# Altium schematic sheet STM32-ROMI_Rev_A_1_STM32.SchDoc(STM32-ROMI_Rev_A_1_STM32)
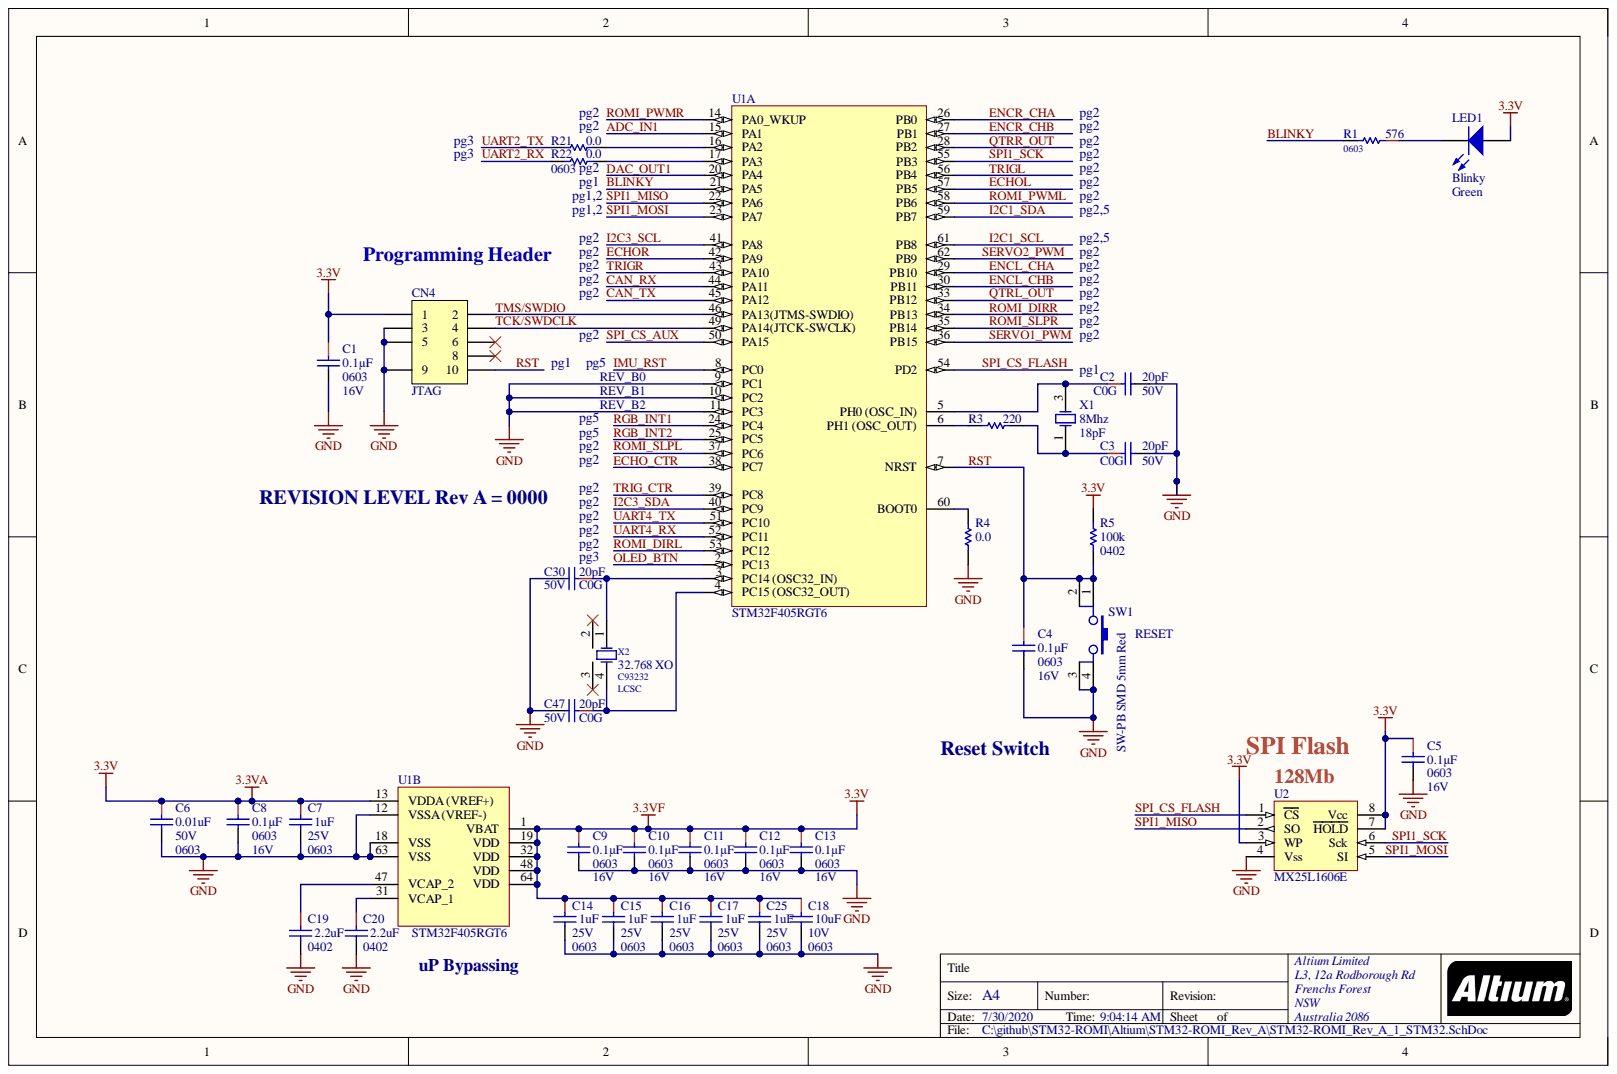
[diagram p0: STM32-ROMI_Rev_A_1_STM32.SchDoc]

## Parts
C1  Capacitance: 0.1µF  Package / Case: 0603  Voltage - Rated: 16V  [CAPC0603(1608)_L]  (hidden comment: 0.1uF)
C2  Capacitance: 20pF  Temperature Coefficient: C0G  Voltage - Rated: 50V  [CAPC0402(1005)_L]  (hidden comment: 20pF 0402)
C3  Capacitance: 20pF  Temperature Coefficient: C0G  Voltage - Rated: 50V  [CAPC0402(1005)_L]  (hidden comment: 20pF 0402)
C4  Capacitance: 0.1µF  Package / Case: 0603  Voltage - Rated: 16V  [CAPC0603(1608)_L]  (hidden comment: 0.1uF)
C5  Capacitance: 0.1µF  Package / Case: 0603  Voltage - Rated: 16V  [CAPC0603(1608)_L]  (hidden comment: 0.1uF)
C6  0.01uF  Package / Case: 0603  Voltage - Rated: 50V  [CAPC0603(1608)_L]
C7  1uF  Package / Case: 0603  Voltage - Rated: 25V  [CAPC0603(1608)_N]
C8  0.1uF  Capacitance: 0.1µF  Package / Case: 0603  Voltage - Rated: 16V  [CAPC0603(1608)_L]
C9  Capacitance: 0.1µF  Package / Case: 0603  Voltage - Rated: 16V  [CAPC0603(1608)_L]  (hidden comment: 0.1uF)
C10  Capacitance: 0.1µF  Package / Case: 0603  Voltage - Rated: 16V  [CAPC0603(1608)_L]  (hidden comment: 0.1uF)
C11  Capacitance: 0.1µF  Package / Case: 0603  Voltage - Rated: 16V  [CAPC0603(1608)_L]  (hidden comment: 0.1uF)
C12  Capacitance: 0.1µF  Package / Case: 0603  Voltage - Rated: 16V  [CAPC0603(1608)_L]  (hidden comment: 0.1uF)
C13  Capacitance: 0.1µF  Package / Case: 0603  Voltage - Rated: 16V  [CAPC0603(1608)_L]  (hidden comment: 0.1uF)
C14  1uF  Package / Case: 0603  Voltage - Rated: 25V  [CAPC0603(1608)_N]
C15  1uF  Package / Case: 0603  Voltage - Rated: 25V  [CAPC0603(1608)_N]
C16  1uF  Package / Case: 0603  Voltage - Rated: 25V  [CAPC0603(1608)_N]
C17  1uF  Package / Case: 0603  Voltage - Rated: 25V  [CAPC0603(1608)_N]
C18  10uF  Package / Case: 0603  Voltage - Rated: 10V  [CAPC0603(1608)_L]
C19  2.2uF  Package / Case: 0402  [CAPC0402(1005)_L]
C20  2.2uF  Package / Case: 0402  [CAPC0402(1005)_L]
C25  1uF  Package / Case: 0603  Voltage - Rated: 25V  [CAPC0603(1608)_N]
C30  Capacitance: 20pF  Temperature Coefficient: C0G  Voltage - Rated: 50V  [CAPC0402(1005)_L]  (hidden comment: 20pF 0402)
C47  Capacitance: 20pF  Temperature Coefficient: C0G  Voltage - Rated: 50V  [CAPC0402(1005)_L]  (hidden comment: 20pF 0402)
CN4  JTAG  [BOBS FAVORITE DEBUG HDR]
LED1  Blinky  Color: Green  [SM-LED-0603]
R1  576  Part Size: 0603  [RESC0603(1608)_N]
R3  220  [RESC0402(1005)_L]
R4  0  Resistance (Ohms): 0.0  [RESC0402(1005)_L]
R5  Resistance (Ohms): 100k  Supplier Device Package: 0402  [RESC0402(1005)_L]  (hidden comment: 100K 0402)
R21  Resistance (Ohms): 0.0  [RESC0603(1608)_N]  (hidden comment: 0 0603)
R22  Package / Case: 0603  Resistance (Ohms): 0.0  [RESC0603(1608)_N]  (hidden comment: 0 0603)
SW1  RESET  [SW TACT Red 6mm x 6mm]
U1  STM32F405RGT6  [STM-LQFP64_N]  (parts A,B)
U2  MX25L1606E  [SOG.050/8D]
X1  8Mhz  Load Capacitance: 18pF  [SMXOSC/5x3.2]
X2  32.768 XO  Supplier 2: LCSC  Supplier Part Number 2: C93232  [OSCABS]

## Nets
3.3V: C1.1 C5.1 C6.1 C7.1 C8.1 C9.1 C10.1 C11.1 C12.1 C13.1 C14.1 C15.1 C16.1 C17.1 C18.1 C25.1 CN4.1 LED1.1 R5.2 U1.1(VBAT) U1.13(VDDA_(VREF+)) U1.19(VDD) U1.32(VDD) U1.48(VDD) U1.64(VDD) U2.3(WP) U2.7(HOLD) U2.8(Vcc)
GND: C1.2 C2.2 C3.2 C4.2 C5.2 C6.2 C7.2 C8.2 C9.2 C10.2 C11.2 C12.2 C13.2 C14.2 C15.2 C16.2 C17.2 C18.2 C19.2 C20.2 C25.2 C30.2 C47.2 CN4.3 CN4.5 CN4.9 R4.1 SW1.3 SW1.4 U1.9(PC1) U1.10(PC2) U1.11(PC3) U1.12(VSSA_(VREF-)) U1.18(VSS) U1.63(VSS) U2.4(Vss)
ADC_IN1: U1.15(PA1)
BLINKY: R1.2 U1.21(PA5)
CAN_RX: U1.44(PA11)
CAN_TX: U1.45(PA12)
DAC_OUT1: U1.20(PA4)
ECHO_CTR: U1.38(PC7)
ECHOL: U1.57(PB5)
ECHOR: U1.42(PA9)
ENCL_CHA: U1.29(PB10)
ENCL_CHB: U1.30(PB11)
ENCR_CHA: U1.26(PB0)
ENCR_CHB: U1.27(PB1)
I2C1_SCL: U1.61(PB8)
I2C1_SDA: U1.59(PB7)
I2C3_SCL: U1.41(PA8)
I2C3_SDA: U1.40(PC9)
IMU_RST: U1.8(PC0)
OLED_BTN: U1.2(PC13)
QTRL_OUT: U1.33(PB12)
QTRR_OUT: U1.28(PB2)
RGB_INT1: U1.24(PC4)
RGB_INT2: U1.25(PC5)
ROMI_DIRL: U1.53(PC12)
ROMI_DIRR: U1.34(PB13)
ROMI_PWML: U1.58(PB6)
ROMI_PWMR: U1.14(PA0_WKUP)
ROMI_~{SLPL}: U1.37(PC6)
ROMI_~{SLPR}: U1.35(PB14)
RST: C4.1 CN4.10 R5.1 SW1.1 SW1.2 U1.7(NRST)
SERVO1_PWM: U1.36(PB15)
SERVO2_PWM: U1.62(PB9)
SPI1_MISO: U1.22(PA6) U2.2(SO)
SPI1_MOSI: U1.23(PA7) U2.5(SI)
SPI1_SCK: U1.55(PB3) U2.6(Sck)
SPI_CS_AUX: U1.50(PA15)
SPI_CS_FLASH: U1.54(PD2) U2.1(CS)
TCK/SWDCLK: CN4.4 U1.49(PA14(JTCK-SWCLK))
TMS/SWDIO: CN4.2 U1.46(PA13(JTMS-SWDIO))
TRIG_CTR: U1.39(PC8)
TRIGL: U1.56(PB4)
TRIGR: U1.43(PA10)
UART2_RX: R22.2
UART2_TX: R21.2
UART4_RX: U1.52(PC11)
UART4_TX: U1.51(PC10)
NetC2_1: C2.1 U1.5(PH0_(OSC_IN)) X1.3
NetC3_1: C3.1 R3.1 X1.1
NetC19_1: C19.1 U1.47(VCAP_2)
NetC20_1: C20.1 U1.31(VCAP_1)
NetC30_1: C30.1 U1.3(PC14_(OSC32_IN)) X2.1
NetC47_1: C47.1 U1.4(PC15_(OSC32_OUT)) X2.4
NetLED1_2: LED1.2 R1.1
NetR3_2: R3.2 U1.6(PH1_(OSC_OUT))
NetR4_2: R4.2 U1.60(BOOT0)
NetR21_1: R21.1 U1.16(PA2)
NetR22_1: R22.1 U1.17(PA3)

Not connected: CN4 6 8; X2 2 3

## Library data of the parts
C1 C4 C5 C8-C13 = CAP CER 0.1UF 16V 10% X7R 0603: 0.1uF 0603 16V; Manufacturer: Kemet; Manufacturer Part Number: C0603C104K4RACTU; Temperature Coefficient: X7R; Tolerance: ±10%; Applications: General Purpose; Category: Capacitors; Component Kind: Standard; ComponentLink1Description: http://www.kemet.com/datasheets&C0603C104K4RACTU; ComponentLink1URL: http://www.kemet.com/datasheets&C0603C104K4RACTU; Features: -; Height - Seated (Max): -; Lead Spacing: -; Lead Style: -; Library Name: JLCPCB_Passives.IntLib; Mounting Type: Surface Mount, MLCC; Operating Temperature: -55°C ~ 125°C; Packaging: Tape & Reel (TR); Pricing 1: 4000=0.00414, 8000=0.00378, 12000=0.0036, 28000=0.00342, 100000=0.00248 (USD); Ratings: -; RoHS: RoHS Compliant; Size / Dimension: 0.063" L x 0.031" W (1.60mm x 0.80mm); Stock 1: 2360000; Supplier 1: Digi-Key; Supplier Part Number 1: 399-1096-2-ND; Thickness (Max): 0.034" (0.87mm)
C2 C3 C30 C47 = CAP CER 20PF 50V 2% NP0 0402: Manufacturer: Murata Electronics North America; Manufacturer Part Number: GRM1555C1H200GA01D; Tolerance: ±2%; Applications: General Purpose; Category: Capacitors; Component Kind: Standard; ComponentLink1Description: http://www.murata.com/~/media/webrenewal/support/library/catalog/products/capacitor/mlcc/c02e.ashx; ComponentLink1URL: http://www.murata.com/~/media/webrenewal/support/library/catalog/products/capacitor/mlcc/c02e.ashx; Description: 20pF 0402; Features: -; Height - Seated (Max): -; Lead Spacing: -; Lead Style: -; Library Name: OLogic-Passive.IntLib; Mounting Type: Surface Mount, MLCC; Operating Temperature: -55°C ~ 125°C; Packaging: Cut Tape (CT); Pricing 1: 1=0.11, 10=0.082, 50=0.0444, 100=0.0376, 250=0.03076, 500=0.02632, 1000=0.0201, 5000=0.01675 (USD); Ratings: -; RoHS: RoHS Compliant; Size / Dimension: 0.039" L x 0.020" W (1.00mm x 0.50mm); Stock 1: 80973; Supplier 1: Digi-Key; Supplier Part Number 1: 490-6217-1-ND; Thickness (Max): 0.022" (0.55mm); Creator: osman
C6 = CAP CER 0.01uF 50V 10% X7R 0603: 0.01uF 50V 0603; Manufacturer: Kemet; Manufacturer Part Number: C0603C103K5RACTU; Capacitance: 10000pF; Temperature Coefficient: X7R; Tolerance: ±10%; Applications: General Purpose; Category: Capacitors; ClassName: MCU; Component Kind: Standard; ComponentLink1Description: http://www.kemet.com/docfinder?Partnumber=C0603C103K5RACTU; ComponentLink1URL: http://www.kemet.com/docfinder?Partnumber=C0603C103K5RACTU; Features: -; Height - Seated (Max): -; Lead Spacing: -; Lead Style: -; Library Name: OLogic-Passive.IntLib; Mounting Type: Surface Mount, MLCC; Operating Temperature: -55°C ~ 125°C; Packaging: Cut Tape (CT); Pricing 1: 1=0.1, 10=0.02, 25=0.014, 50=0.0106, 100=0.009, 250=0.00736, 1000=0.0048 (USD); Ratings: -; RoHS: RoHS Compliant; Size / Dimension: 0.063" L x 0.031" W (1.60mm x 0.80mm); Stock 1: 1304139; Supplier 1: Digi-Key; Supplier Part Number 1: 399-1091-1-ND; Thickness (Max): 0.034" (0.87mm)
C7 C14-C17 C25 = CAP CER 1UF 25V 10% X7R 0603: 1uF 0603 25V; Manufacturer: TDK Corporation; Manufacturer Part Number: CGA3E1X7R1E105K080AC; Temperature Coefficient: X7R; Tolerance: ±10%; Applications: Automotive; Category: Capacitors; Component Kind: Standard; ComponentLink1Description: https://product.tdk.com/info/en/catalog/datasheets/mlcc_automotive_general_en.pdf; ComponentLink1URL: https://product.tdk.com/info/en/catalog/datasheets/mlcc_automotive_general_en.pdf; Failure Rate: -; Features: -; Height - Seated (Max): -; Lead Spacing: -; Lead Style: -; Library Name: OLogic-Passive.IntLib; Mounting Type: Surface Mount, MLCC; Operating Temperature: -55°C ~ 125°C; Packaging: Cut Tape (CT); Part Status: Active; Pricing 1: 1=0.2, 10=0.135, 100=0.0686, 500=0.04696, 1000=0.04154 (USD); Ratings: AEC-Q200; RoHS: RoHS Compliant; Size / Dimension: 0.063" L x 0.032" W (1.60mm x 0.80mm); Stock 1: 360610; Supplier 1: Digi-Key; Supplier Part Number 1: 445-6931-1-ND; Thickness (Max): 0.035" (0.90mm)
C18 = CAP CER 10UF 10V 20% X5R 0603: 10uF 0603 10V; Manufacturer: Taiyo Yuden; Manufacturer Part Number: LMK107BBJ106MAHT; Capacitance: 10µF; Temperature Coefficient: X5R; Tolerance: ±20%; Applications: Automotive; Category: Capacitors; Component Kind: Standard; ComponentLink1Description: http://www.yuden.co.jp/productdata/catalog/en/mlcc_all_e.pdf; ComponentLink1URL: http://www.yuden.co.jp/productdata/catalog/en/mlcc_all_e.pdf; Features: -; Height - Seated (Max): -; Lead Spacing: -; Lead Style: -; Library Name: OLogic-Passive.IntLib; Mounting Type: Surface Mount, MLCC; Operating Temperature: -55°C ~ 85°C; Packaging: Cut Tape (CT); Pricing 1: 1=0.4, 10=0.348, 50=0.2116, 100=0.1742, 250=0.13936, 500=0.12692, 1000=0.11224 (USD); Ratings: AEC-Q200; RoHS: RoHS Compliant; Size / Dimension: 0.063" L x 0.031" W (1.60mm x 0.80mm); Stock 1: 20252; Supplier 1: Digi-Key; Supplier Part Number 1: 587-3412-1-ND; Thickness (Max): 0.035" (0.90mm)
C19 C20 = CAP CER 2.2UF 35V 10% X5R 0402: 2.2uF 0402; Manufacturer: TDK Corporation; Manufacturer Part Number: C1005X5R1V225K050BC; Capacitance: 2.2µF; Temperature Coefficient: X5R; Tolerance: ±10%; Voltage - Rated: 35V; Applications: General Purpose; Category: Capacitors; Component Kind: Standard; ComponentLink1Description: http://product.tdk.com/en/catalog/datasheets/mlcc_commercial_general_en.pdf; ComponentLink1URL: http://product.tdk.com/en/catalog/datasheets/mlcc_commercial_general_en.pdf; Features: -; Height - Seated (Max): -; Lead Spacing: -; Lead Style: -; Library Name: OLogic-Passive.IntLib; Mounting Type: Surface Mount, MLCC; Operating Temperature: -55°C ~ 85°C; Packaging: Cut Tape (CT); Pricing 1: 1=0.32, 10=0.28, 100=0.14, 250=0.112, 500=0.102, 1000=0.092, 5000=0.076 (USD); Ratings: -; RoHS: RoHS Compliant; Size / Dimension: 0.039" L x 0.020" W (1.00mm x 0.50mm); Stock 1: 43689; Supplier 1: Digi-Key; Supplier Part Number 1: 445-9028-1-ND; Thickness (Max): 0.022" (0.55mm); Creator: osman
CN4 = CONN-2x5-SWD: SAMTEC - FTSH-105-01-L-DV - HEADER, 1.27MM, SMD, 10WAY; Connector Type: Header, Unshrouded; Number of Positions: 10; Number of Positions Loaded: All; Pitch: 0.050" (1.27mm); PN: SAM1161-05-ND; Vendor: Digikey; Category: Connectors, Interconnects; ClassName: mcu; Color: Black; Component Kind: Standard; ComponentLink1Description: http://www.samtec.com/documents/webfiles/cpdf/FTSH-1XX-XX-XXX-DV-XXX-MKT.pdf; ComponentLink1URL: http://www.samtec.com/documents/webfiles/cpdf/FTSH-1XX-XX-XXX-DV-XXX-MKT.pdf; Contact Finish: Gold; Contact Finish Thickness: 10µin (0.25µm); Contact Mating Length: 0.120" (3.05mm); Contact Type: Male Pin; Fastening Type: -; Features: -; Library Name: OLogic-Connectors.IntLib; Manufacturer: Samtec; Manufacturer Part Number: FTSH-105-01-L-DV; Mounting Type: Surface Mount; MPN: FTSH-105-01-L-DV; Number of Rows: 2; Packaging: Bulk; Pricing 1: 1=3.42, 10=2.963, 100=2.5073, 500=1.99444, 1000=1.62404, 5000=1.48158, 10000=1.4246 (USD); RoHS: RoHS Compliant; Row Spacing: 0.050" (1.27mm); Stock 1: 0; Supplier 1: Digi-Key; Supplier Part Number 1: SAM1161-05-ND; Termination: Solder
LED1 = LTST-C191KGKT: LED GREEN CLEAR THIN 0603 SMD; Manufacturer: Lite-On Inc; Current - Test: 20mA; Lens Style/Size: Rectangle with Flat Top, 1.1mm x 0.8mm; Millicandela Rating: 35mcd; Viewing Angle: 130°; Voltage - Forward (Vf) (Typ): 2V; Wavelength - Dominant: 571nm; Wavelength - Peak: 574nm; Category: Optoelectronics; Component Kind: Standard; ComponentLink1Description: http://optoelectronics.liteon.com/upload/download/DS22-2000-228/S_110_LTST-C191KGKT.pdf; ComponentLink1URL: http://optoelectronics.liteon.com/upload/download/DS22-2000-228/S_110_LTST-C191KGKT.pdf; Height: 0.55mm; Lens Type: Clear; Luminous Flux @ Current - Test: -; Manufacturer Part Number: LTST-C191KGKT; Mounting Type: Surface Mount; Package / Case: 0603 (1608 Metric); Packaging: Cut Tape (CT); Pricing 1: 1=0.3, 10=0.238, 100=0.1296, 250=0.0864, 500=0.07344, 1000=0.05616, 2500=0.05076 (USD); RoHS: RoHS Compliant; Size / Dimension: 1.60mm L x 0.80mm W; Stock 1: 84829; Supplier 1: Digi-Key; Supplier Part Number 1: 160-1446-1-ND; Library Name: Kyle-Diode-Opto-LED.IntLib
R1 = 576: 576OHMS ±1% 1/10W 0603; Manufacturer Part Number: 0603WAF5760T5E; Component Kind: Standard; Library Name: JLCPCB_Passives.IntLib; Part Supplier 1: LCSC
R3 = RES 220 OHM 1% 1/10W 0402: 220 0402; Manufacturer: Panasonic Electronic Components; Manufacturer Part Number: ERJ-2RKF2200X; Power (Watts): 0.1W, 1/10W; Temperature Coefficient: ±100ppm/°C; Tolerance: ±1%; Category: Resistors; Component Kind: Standard; ComponentLink1Description: http://industrial.panasonic.com/www-cgi/jvcr13pz.cgi?E+PZ+3+AOA0002+ERJ2RKF2200X+7+WW; ComponentLink1URL: http://industrial.panasonic.com/www-cgi/jvcr13pz.cgi?E+PZ+3+AOA0002+ERJ2RKF2200X+7+WW; Composition: Thick Film; Features: Automotive AEC-Q200; Height: 0.016" (0.40mm); Library Name: OLogic-Passive.IntLib; Number of Terminations: 2; Operating Temperature: -55°C ~ 155°C; Package / Case: 0402 (1005 Metric); Packaging: Cut Tape (CT); Pricing 1: 1=0.1, 50=0.0196, 100=0.0149, 250=0.01104, 500=0.00816, 1000=0.00544, 5000=0.00383 (USD); Resistance (Ohms): 220; RoHS: RoHS Compliant; Size / Dimension: 0.039" L x 0.020" W (1.00mm x 0.50mm); Stock 1: 372365; Supplier 1: Digi-Key; Supplier Device Package: 0402; Supplier Part Number 1: P220LCT-ND; Creator: osman
R4 = RES 0.0 OHM JUMPER 1/10W 0402: 0.0 0402; Manufacturer: Panasonic Electronic Components; Manufacturer Part Number: ERJ-2GE0R00X; Power (Watts): 0.1W, 1/10W; Tolerance: Jumper; Category: Resistors; Component Kind: Standard; ComponentLink1Description: http://industrial.panasonic.com/www-cgi/jvcr13pz.cgi?E+PZ+3+AOA0001+ERJ2GE0R00X+7+WW; ComponentLink1URL: http://industrial.panasonic.com/www-cgi/jvcr13pz.cgi?E+PZ+3+AOA0001+ERJ2GE0R00X+7+WW; Composition: Thick Film; Features: Automotive AEC-Q200; Height: 0.016" (0.40mm); Library Name: OLogic-Passive.IntLib; Number of Terminations: 2; Operating Temperature: -55°C ~ 155°C; Package / Case: 0402 (1005 Metric); Packaging: Cut Tape (CT); Pricing 1: 1=0.1, 50=0.0138, 100=0.0105, 250=0.0078, 500=0.00576, 1000=0.00384, 5000=0.0027 (USD); RoHS: RoHS Compliant; Size / Dimension: 0.039" L x 0.020" W (1.00mm x 0.50mm); Stock 1: 8429476; Supplier 1: Digi-Key; Supplier Device Package: 0402; Supplier Part Number 1: P0.0JCT-ND; Temperature Coefficient: -; Creator: osman
R5 = RES 100K OHM 1% 1/16W 0402: Manufacturer: Yageo; Manufacturer Part Number: RC0402FR-07100KL; Power (Watts): 0.1W, 1/10W; Temperature Coefficient: ±100ppm/°C; Tolerance: ±1%; Category: Resistors; Component Kind: Standard; ComponentLink1Description: http://industrial.panasonic.com/www-cgi/jvcr13pz.cgi?E+PZ+3+AOA0002+ERJ2RKF1003X+7+WW; ComponentLink1URL: http://industrial.panasonic.com/www-cgi/jvcr13pz.cgi?E+PZ+3+AOA0002+ERJ2RKF1003X+7+WW; Composition: Thick Film; Description: 100K 0402; Features: Automotive AEC-Q200; Height: 0.016" (0.40mm); Library Name: OLogic-Passive.IntLib; Number of Terminations: 2; Operating Temperature: -55°C ~ 155°C; Package / Case: 0402 (1005 Metric); Packaging: Cut Tape (CT); Pricing 1: 1=0.1, 50=0.0196, 100=0.0149, 250=0.01104, 500=0.00816, 1000=0.00544, 5000=0.00383 (USD); RoHS: RoHS Compliant; Size / Dimension: 0.039" L x 0.020" W (1.00mm x 0.50mm); Stock 1: 3706939; Supplier 1: Digi-Key; Supplier 2: Digi-Key; Supplier Part Number 1: 311-100KLRCT-ND; Supplier Part Number 2: RMCF0402FT100KCT-ND
R21 R22 = RES 0.0 OHM 1/4W JUMP 0603 SMD: Manufacturer: Vishay Dale; Manufacturer Part Number: CRCW06030000Z0EAHP; Power (Watts): 1/4W; Tolerance: Jumper; Category: Resistors; Component Kind: Standard; ComponentLink1Description: http://www.vishay.com/docs/20043/crcwhpe3.pdf; ComponentLink1URL: http://www.vishay.com/docs/20043/crcwhpe3.pdf; Composition: Thick Film; Description: 0 0603; Features: Automotive AEC-Q200 Qualified, Pulse Withstanding; Height: 0.022" (0.55mm); Library Name: OLogic-Passive.IntLib; Number of Terminations: 2; Packaging: Cut Tape (CT); Pricing 1: 10=0.202, 50=0.1088, 200=0.0625, 1000=0.04263 (USD); RoHS: RoHS Compliant; Signal Integrity: Res; Simulation: RESISTOR; Size / Dimension: 0.063" L x 0.033" W (1.60mm x 0.85mm); Stock 1: 204741; Supplier 1: Digi-Key; Supplier Device Package: 0603 (1608 Metric); Supplier Part Number 1: 541-0.0SBCT-ND; Temperature Coefficient: -
  R21: Package / Case: 0603
SW1 = SW-PB SMD 5mm Red: SWITCH TACTILE SPST-NO 0.05A 12V; Manufacturer: C&K Components; Manufacturer Part Number: PTS645SK50SMTR92 LFS; Actuator Height off PCB, from Pins: 5.00mm; Actuator Material: Polyamide (PA66), Nylon 6/6; Actuator Orientation: Top Actuated; Actuator Type: Standard; Contact Rating @ Voltage: 0.05A @ 12VDC; Illumination: Non-Illuminated; Mechanical Life: 100,000 Cycles; Operating Force: 260gf; Outline: 6.00mm x 6.00mm; Switch Function: Off-Mom; Category: Switches; Circuit: SPST-NO; ClassName: USwitch; Component Kind: Standard; ComponentLink1Description: http://www.ck-components.com/14409/pts645_25apr13.pdf/; ComponentLink1URL: http://www.ck-components.com/14409/pts645_25apr13.pdf/; Features: -; Illumination Type, Color: -; Illumination Voltage (Nominal): -; Ingress Protection: -; LatestRevisionDate: 17-Jul-2002; LatestRevisionNote: Re-released for DXP Platform.; Library Name: OLogic-Switches.IntLib; Mounting Type: Surface Mount; Operating Temperature: -20°C ~ 60°C; PackageDescription: Switch; 2 Leads; PackageReference: SPST-2; Packaging: Cut Tape (CT); Pricing 1: 1=0.28, 10=0.271, 25=0.262, 50=0.2524, 100=0.2384, 250=0.2244, 500=0.21506 (USD); Published: 24-Mar-1999; RoHS: RoHS Compliant; Stock 1: 24906; Supplier 1: Digi-Key; Supplier Part Number 1: CKN9087CT-ND; Switch Travel: -; Termination Style: Gull Wing; Text: SW-PB SMD 5mm Red; Publisher: Altium Limited
U1 = STM32F405RGT6: IC MCU 32BIT 1MB FLASH 64LQFP; Manufacturer: STMicroelectronics; Connectivity: CAN, I²C, IrDA, LIN, SPI, UART/USART, USB OTG; Core Processor: ARM® Cortex®-M4; Core Size: 32-Bit; Data Converters: A/D 16x12b, D/A 2x12b; Number of I/O: 51; Oscillator Type: Internal; Peripherals: Brown-out Detect/Reset, DMA, I²S, LCD, POR, PWM, WDT; Program Memory Size: 1MB (1M x 8); RAM Size: 192K x 8; Speed: 168MHz; Voltage - Supply (Vcc/Vdd): 1.8 V ~ 3.6 V; Category: Integrated Circuits (ICs); Component Kind: Standard; ComponentLink1Description: http://www.st.com/web/en/resource/technical/document/datasheet/DM00037051.pdf; ComponentLink1URL: http://www.st.com/web/en/resource/technical/document/datasheet/DM00037051.pdf; EEPROM Size: -; Library Name: OLogic-CPU-Memory.IntLib; Manufacturer Part Number: STM32F405RGT6; Operating Temperature: -40°C ~ 85°C (TA); Package / Case: 64-LQFP; Packaging: Tray; Pricing 1: 1=10.93, 10=10.044, 100=8.4825, 500=7.5458, 1000=6.92132 (USD); Program Memory Type: FLASH; RoHS: RoHS Compliant; Stock 1: 14614; Supplier 1: Digi-Key; Supplier Device Package: 64-LQFP (10x10); Supplier Part Number 1: 497-11767-ND
U2 = MX25L1606E: 16M NOR Flash; Manufacturer: Macronix; Manufacturer Part Number: MX25L1606EM2I-12G; Interface: SPI Serial; Memory Size: 16M (16M x 1, 8M x 2); Memory Type: FLASH - NOR; PN: 1092-1135-ND; Speed: 86MHz; Vendor: Digikey; Voltage - Supply: 2.7 V ~ 3.6 V; Category: Integrated Circuits (ICs); Component Kind: Standard; ComponentLink1Description: http://www.macronix.com/Lists/ApplicationNote/Attachments/654/AN055-Migrate_to_MX25L1606E_and_MX25L8006E-1.2.pdf; ComponentLink1URL: http://www.macronix.com/Lists/ApplicationNote/Attachments/654/AN055-Migrate_to_MX25L1606E_and_MX25L8006E-1.2.pdf; Format - Memory: FLASH; Library Name: OLogic-CPU-Memory.IntLib; MPN: MX25L1606EM2I-12G; Operating Temperature: -40°C ~ 85°C; Package / Case: 8-SOIC (0.209", 5.30mm Width); Packaging: Tube; Pricing 1: 1=1.04, 10=0.947, 50=0.9292, 100=0.8309, 250=0.82368, 500=0.81168, 1000=0.7824, 2500=0.76032, 5000=0.7128 (USD); RoHS: RoHS Compliant; Stock 1: 1520; Supplier 1: Digi-Key; Supplier Device Package: 8-SOP; Supplier Part Number 1: 1092-1135-ND
X1 = CRYSTAL 8MHZ ABM3: 8Mhz ABM3 Parallel Load Crystal; Manufacturer: Abracon Corporation; Manufacturer Part Number: ABM3B-8.000MHZ-B2-T; ESR (Equivalent Series Resistance): 200 Ohm; Frequency Stability: ±50ppm; Frequency Tolerance: ±20ppm; Operating Mode: Fundamental; Category: Crystals and Oscillators; ClassName: MCU; Component Kind: Standard; ComponentLink1Description: http://www.abracon.com/Resonators/abm3b.pdf; ComponentLink1URL: http://www.abracon.com/Resonators/abm3b.pdf; Frequency: 8MHz; Height: 0.043" (1.10mm); Library Name: OLogic-RF-Osc.IntLib; Mounting Type: Surface Mount; Operating Temperature: -20°C ~ 70°C; Package / Case: 4-SMD, No Lead (DFN, LCC); Packaging: Cut Tape (CT); Pricing 1: 1=1.04, 10=0.92, 50=0.8588, 100=0.76, 500=0.7182 (USD); Ratings: -; RoHS: RoHS Compliant; Size / Dimension: 0.197" L x 0.126" W (5.00mm x 3.20mm); Stock 1: 22545; Supplier 1: Digi-Key; Supplier Part Number 1: 535-9720-1-ND; Type: MHz Crystal
X2 = DST310S 32.768KHz: SMD CRYSTAL RESONATORS 32.768KHZ ±20PPM SMD-3215_2P ROHS; Component Kind: Standard; Library Name: JLCPCB_RF-OSC.IntLib; Supplier 1: Digi-Key; Supplier Part Number 1: 535-10240-1-ND
